# Altium schematic sheet DRV8830M-W_LAN.SchDoc
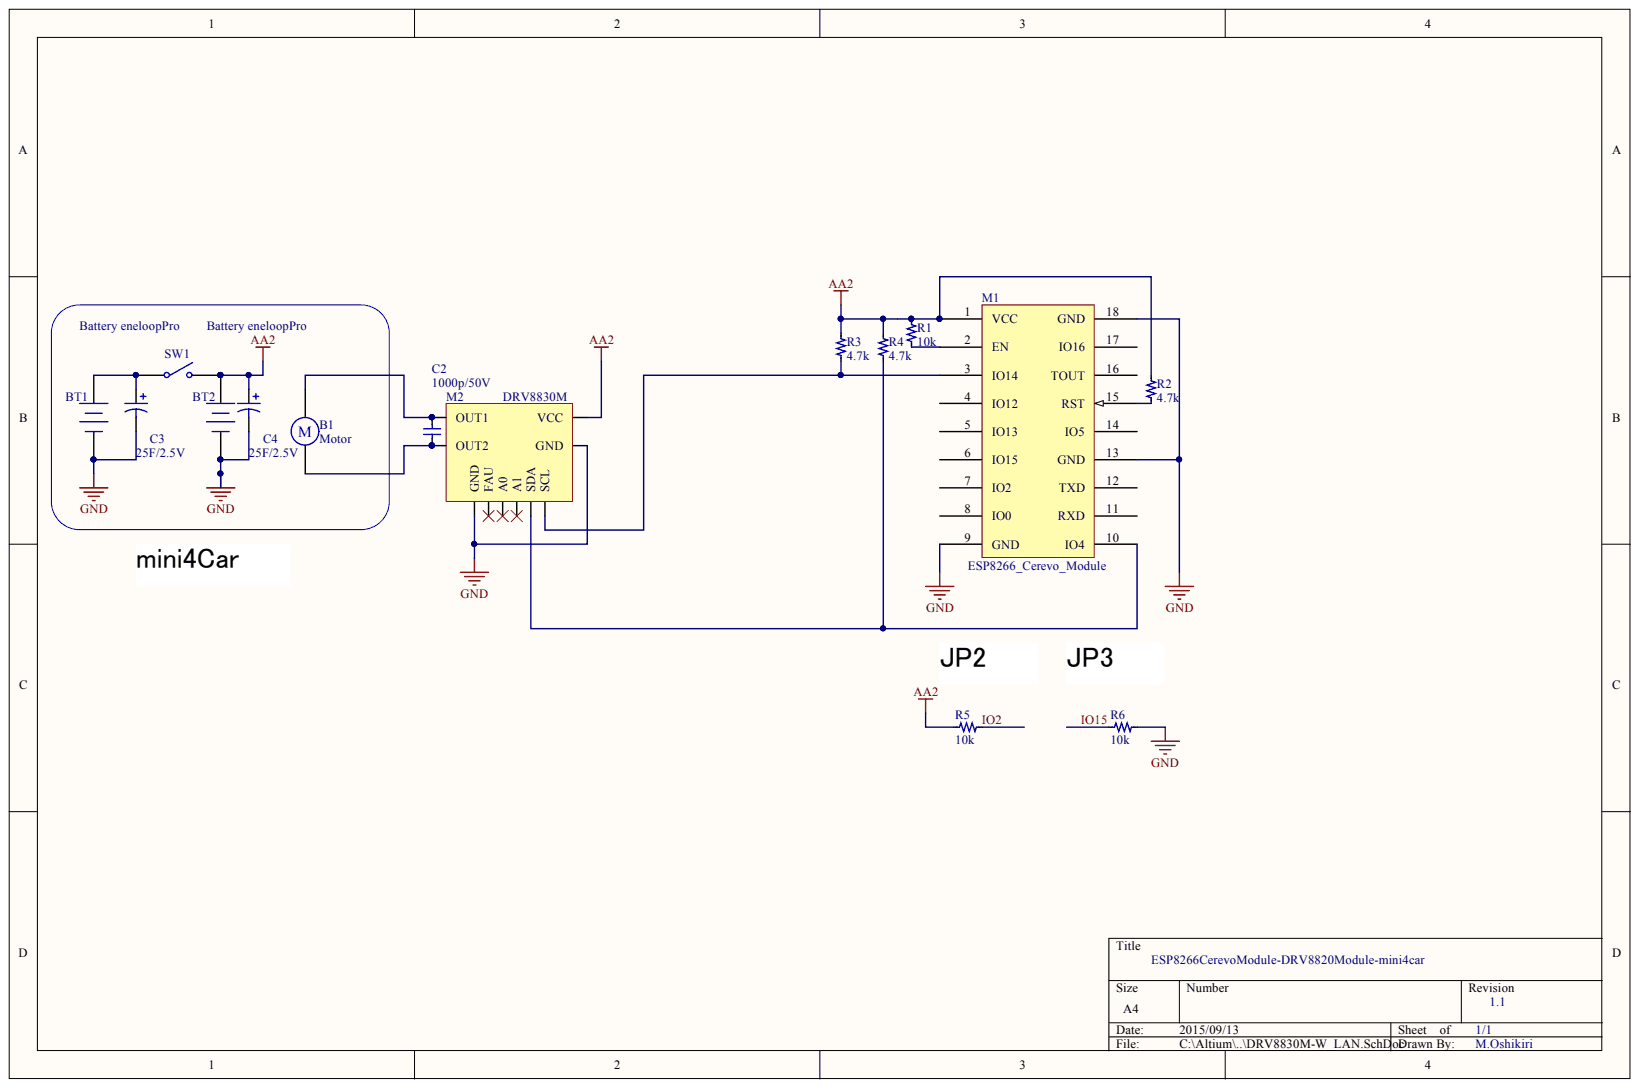
[diagram p0: DRV8830M-W_LAN.SchDoc]

## Parts
B1  Motor  [RB5-10.5]
BT1  Battery eneloopPro  [BAT-2]
BT2  Battery eneloopPro  [BAT-2]
C2  1000p/50V  [C-METRIC-1608]
C3  value 25F/2.5V  [RB7.6-15]  (hidden comment: 100uF/16V)
C4  value 25F/2.5V  [RB7.6-15]  (hidden comment: 100uF/16V)
M1  ESP8266_Cerevo_Module
M2  DRV8830M
R1  10k  [R-METRIC-1608]
R2  4.7k  [R-METRIC-1608]
R3  4.7k  [R-METRIC-1608]
R4  4.7k  [R-METRIC-1608]
R5  10k  [R-METRIC-1608]
R6  10k  [R-METRIC-1608]
SW1  SW-SPST  [SPST-2]  (value not drawn)

## Nets
AA2: BT2.1 C4.1 M1.1(VCC) M2.1 R1.2 R2.2 R3.2 R4.2 R5.2 SW1.2
GND: BT1.2 BT2.2 C3.2 C4.2 M1.9(GND) M1.13(GND) M1.18(GND) M2.1 M2.2 R6.1
IO2: R5.1
IO15: R6.2
NetB1_1: B1.1 C2.1 M2.1
NetB1_2: B1.2 C2.2 M2.2
NetBT1_1: BT1.1 C3.1 SW1.1
NetM1_2: M1.2(EN) R1.1
NetM1_3: M1.3(IO14) M2.2 R3.1
NetM1_10: M1.10(IO4) M2.2 R4.1
NetM1_15: M1.15(RST) R2.1

Not connected: M1 4(IO12) 5(IO13) 6(IO15) 7(IO2) 8(IO0) 11(RXD) 12(TXD) 14(IO5) 16(TOUT) 17(IO16)

## Library data of the parts
B1 = Motor: Motor, General Kind; Component Kind: Standard; LatestRevisionDate: 17-Jul-2002; LatestRevisionNote: Re-released for DXP Platform.; Library Name: Miscellaneous Devices.IntLib; PackageDescription: Capacitor (Radial); 2 Leads; PackageReference: RB5-10.5; Published: 24-Mar-1999; Publisher: Altium Limited
BT1 BT2 = Battery: Multicell Battery; Component Kind: Standard; LatestRevisionDate: 17-Jul-2002; LatestRevisionNote: Re-released for DXP Platform.; Library Name: Miscellaneous Devices.IntLib; PackageDescription: Battery; 2 Leads; PackageReference: BAT-2; Published: 24-Mar-1999; Publisher: Altium Limited
C2 = C1608: Capacitor (non-polar) 2pin; Manufacturer: murata; Component Kind: Standard; Description 1: Capacitor (non-polar); FirstPublished: 2014/12/09; Library Name: RCL.SchLib; Package: 1608metric; Supplier 1: Digi-Key; Supplier 2: MOUSER; Publisher: yuna_digick
C3 C4 = Cap Pol1: Polarized Capacitor (Radial); Component Kind: Standard; LatestRevisionDate: 17-Jul-2002; LatestRevisionNote: Re-released for DXP Platform.; Library Name: Miscellaneous Devices.IntLib; PackageDescription: Polarized Capacitor (Radial); 2 Leads; PackageReference: RB7.6-15; Published: 8-Jun-2000; Signal Integrity: Cap Pol; Simulation: CAP; Publisher: Altium Limited
M1 = ESP8266Module: Component Kind: Standard; Library Name: ESP8266M.SchLib
R1-R6 = R1608: Rsisiter 2pin; Manufacturer: Panasonic; Component Kind: Standard; Description 1: Rsisiter; FirstPublished: 2014/12/09; Library Name: RCL.SchLib; Package: 1608metric; Supplier 1: Digi-Key; Supplier 2: MOUSER; Publisher: yuna_digick
SW1 = SW-SPST: Single-Pole, Single-Throw Switch; Component Kind: Standard; LatestRevisionDate: 17-Jul-2002; LatestRevisionNote: Re-released for DXP Platform.; Library Name: Miscellaneous Devices.IntLib; PackageDescription: Switch; 2 Leads; PackageReference: SPST-2; Published: 24-Mar-1999; Publisher: Altium Limited
M2 = DRV8830M: Component Kind: Standard; Library Name: MotorDriver.SchLib
